# Altium schematic sheet ch340.SchDoc
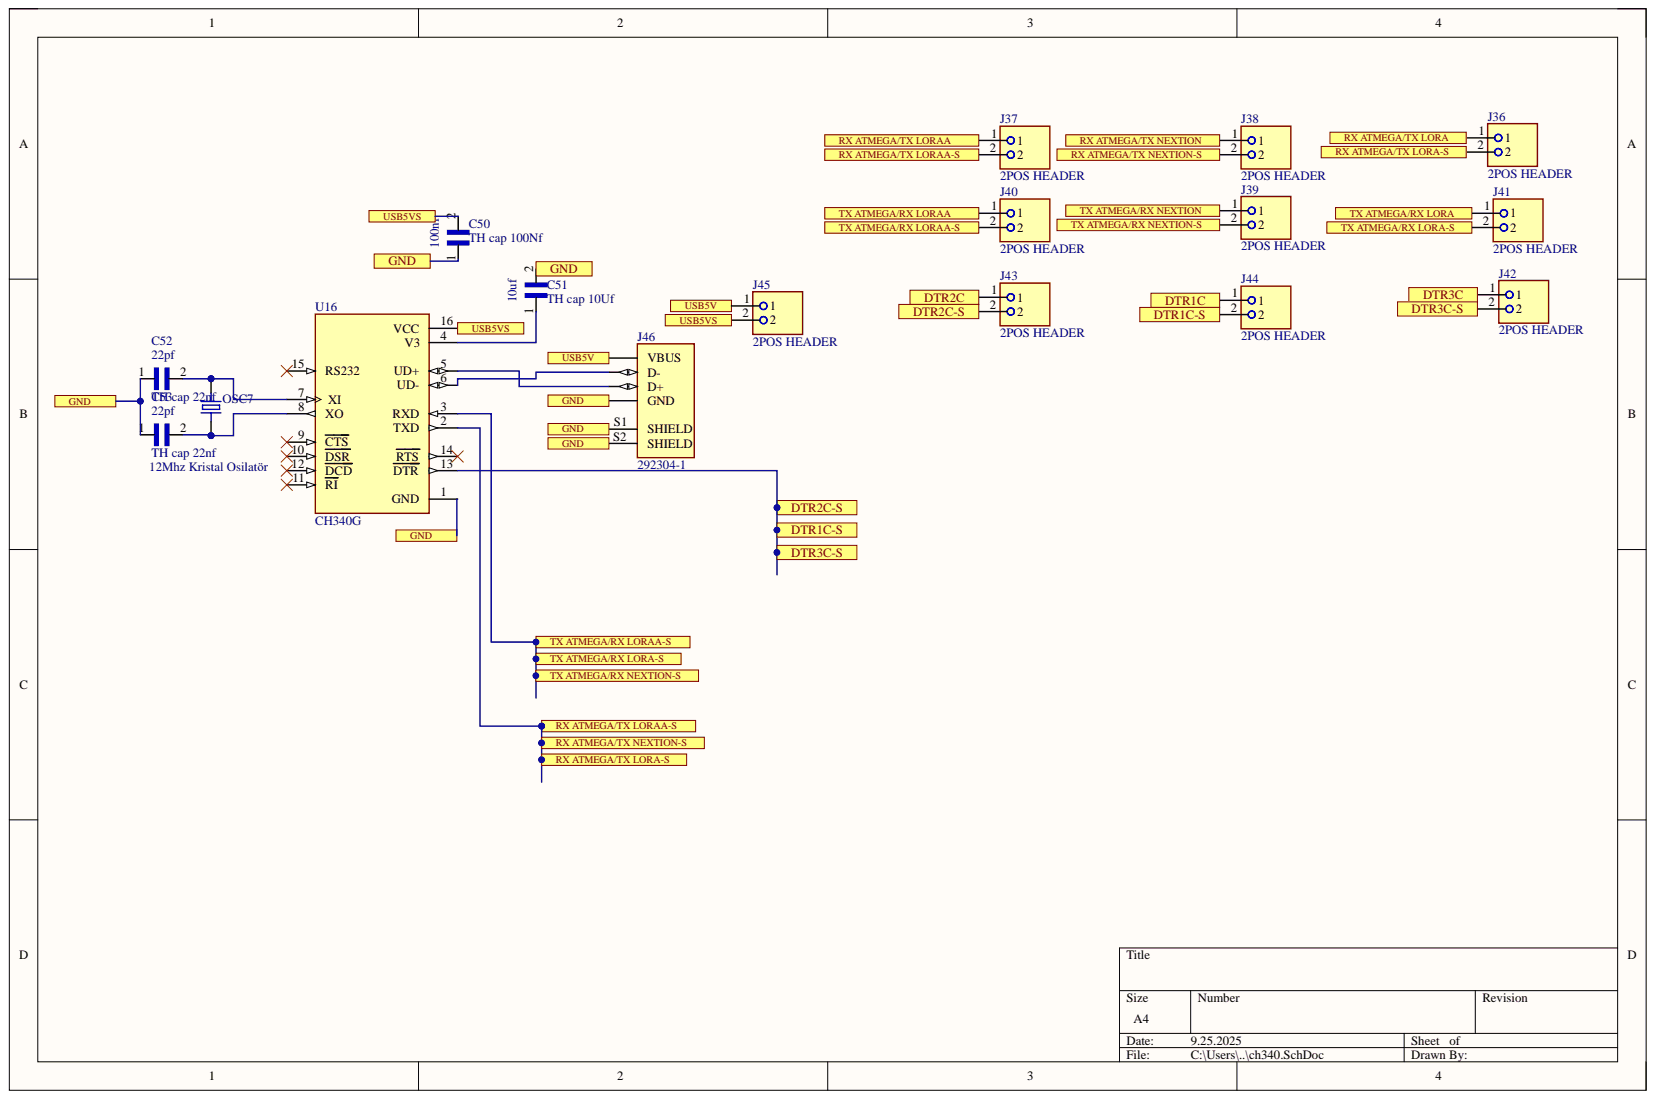
[diagram p0: ch340.SchDoc]

## Parts
C50  TH cap 100Nf  [Kapkucuk]
C51  TH cap 10Uf  [Kapkucuk]
C52  TH cap 22nf  [Kapkucuk]
C53  TH cap 22nf  [Kapkucuk]
J36  2POS HEADER  [1X2 HEADER]
J37  2POS HEADER  [1X2 HEADER]
J38  2POS HEADER  [1X2 HEADER]
J39  2POS HEADER  [1X2 HEADER]
J40  2POS HEADER  [1X2 HEADER]
J41  2POS HEADER  [1X2 HEADER]
J42  2POS HEADER  [1X2 HEADER]
J43  2POS HEADER  [1X2 HEADER]
J44  2POS HEADER  [1X2 HEADER]
J45  2POS HEADER  [1X2 HEADER]
J46  292304-1  [TE_292304-1]
OSC7  12Mhz Kristal Osilatör  [16Mhz Kristal Osilatör]
U16  CH340G  [SOIC127P600X180-16N]

## Ports
DTR1C, DTR1C-S, DTR2C, DTR2C-S, DTR3C, DTR3C-S, GND, RX ATMEGA/TX LORA, RX ATMEGA/TX LORA-S, RX ATMEGA/TX LORAA, RX ATMEGA/TX LORAA-S, RX ATMEGA/TX NEXTION, RX ATMEGA/TX NEXTION-S, TX ATMEGA/RX LORA, TX ATMEGA/RX LORA-S, TX ATMEGA/RX LORAA, TX ATMEGA/RX LORAA-S, TX ATMEGA/RX NEXTION, TX ATMEGA/RX NEXTION-S, USB5V, USB5VS

## Nets
DTR1C: J44.1 ; port DTR1C
DTR1C-S: J42.2 J43.2 J44.2 U16.13(DTR) ; port DTR1C-S, port DTR2C-S, port DTR3C-S
DTR2C: J43.1 ; port DTR2C
DTR3C: J42.1 ; port DTR3C
GND: C50.1 C51.2 C52.1 C53.1 J46.4(GND) J46.S1(SHIELD) J46.S2(SHIELD) U16.1(GND) ; port GND
RX ATMEGA/TX LORA: J36.1 ; port RX ATMEGA/TX LORA
RX ATMEGA/TX LORA-S: J36.2 J37.2 J38.2 U16.2(TXD) ; port RX ATMEGA/TX LORA-S, port RX ATMEGA/TX LORAA-S, port RX ATMEGA/TX NEXTION-S
RX ATMEGA/TX LORAA: J37.1 ; port RX ATMEGA/TX LORAA
RX ATMEGA/TX NEXTION: J38.1 ; port RX ATMEGA/TX NEXTION
TX ATMEGA/RX LORA: J41.1 ; port TX ATMEGA/RX LORA
TX ATMEGA/RX LORA-S: J39.2 J40.2 J41.2 U16.3(RXD) ; port TX ATMEGA/RX LORA-S, port TX ATMEGA/RX LORAA-S, port TX ATMEGA/RX NEXTION-S
TX ATMEGA/RX LORAA: J40.1 ; port TX ATMEGA/RX LORAA
TX ATMEGA/RX NEXTION: J39.1 ; port TX ATMEGA/RX NEXTION
USB5V: J45.1 J46.1(VBUS) ; port USB5V
USB5VS: C50.2 J45.2 U16.16(VCC) ; port USB5VS
NetC51_1: C51.1 U16.4(V3)
NetC52_2: C52.2 OSC7.1 U16.7(XI)
NetC53_2: C53.2 OSC7.2 U16.8(XO)
NetJ46_2: J46.2(D-) U16.6(UD-)
NetJ46_3: J46.3(D+) U16.5(UD+)

Not connected: U16 9(CTS) 10(DSR) 11(RI) 12(DCD) 14(RTS) 15(RS232)

## Library data of the parts
J46 = 292304-1: MF: {'manufacturer': 'TE Connectivity'}; Availability: Unavailable; Component Kind: Standard; Library Name: Copy of Parts.SchLib; MP: 292304-1; Package: None; Price: None
U16 = CH340G: USB Interface Integrated Circuit; MF: WCH; Availability: Not in stock; Check_prices: https://www.snapeda.com/parts/Ch340G/WCH/view-part/?ref=eda; Component Kind: Standard; Library Name: Copy of Parts.SchLib; MP: Ch340G; Package: None; Price: None; SnapEDA_Link: https://www.snapeda.com/parts/Ch340G/WCH/view-part/?ref=snap
C50 = TH cap 100Nf: Component Kind: Standard; Library Name: Copy of Parts.SchLib
C51 = TH cap 10Uf: Component Kind: Standard; Library Name: Copy of Parts.SchLib
C52 C53 = TH cap 22nf: Component Kind: Standard; Library Name: Copy of Parts.SchLib
J36-J45 = 2POS HEADER: Component Kind: Standard; Library Name: Copy of Parts.SchLib
OSC7 = 12Mhz Kristal Osilatör: Component Kind: Standard; Library Name: Copy of Parts.SchLib
